# Altium schematic sheet 1_POWER.SchDoc
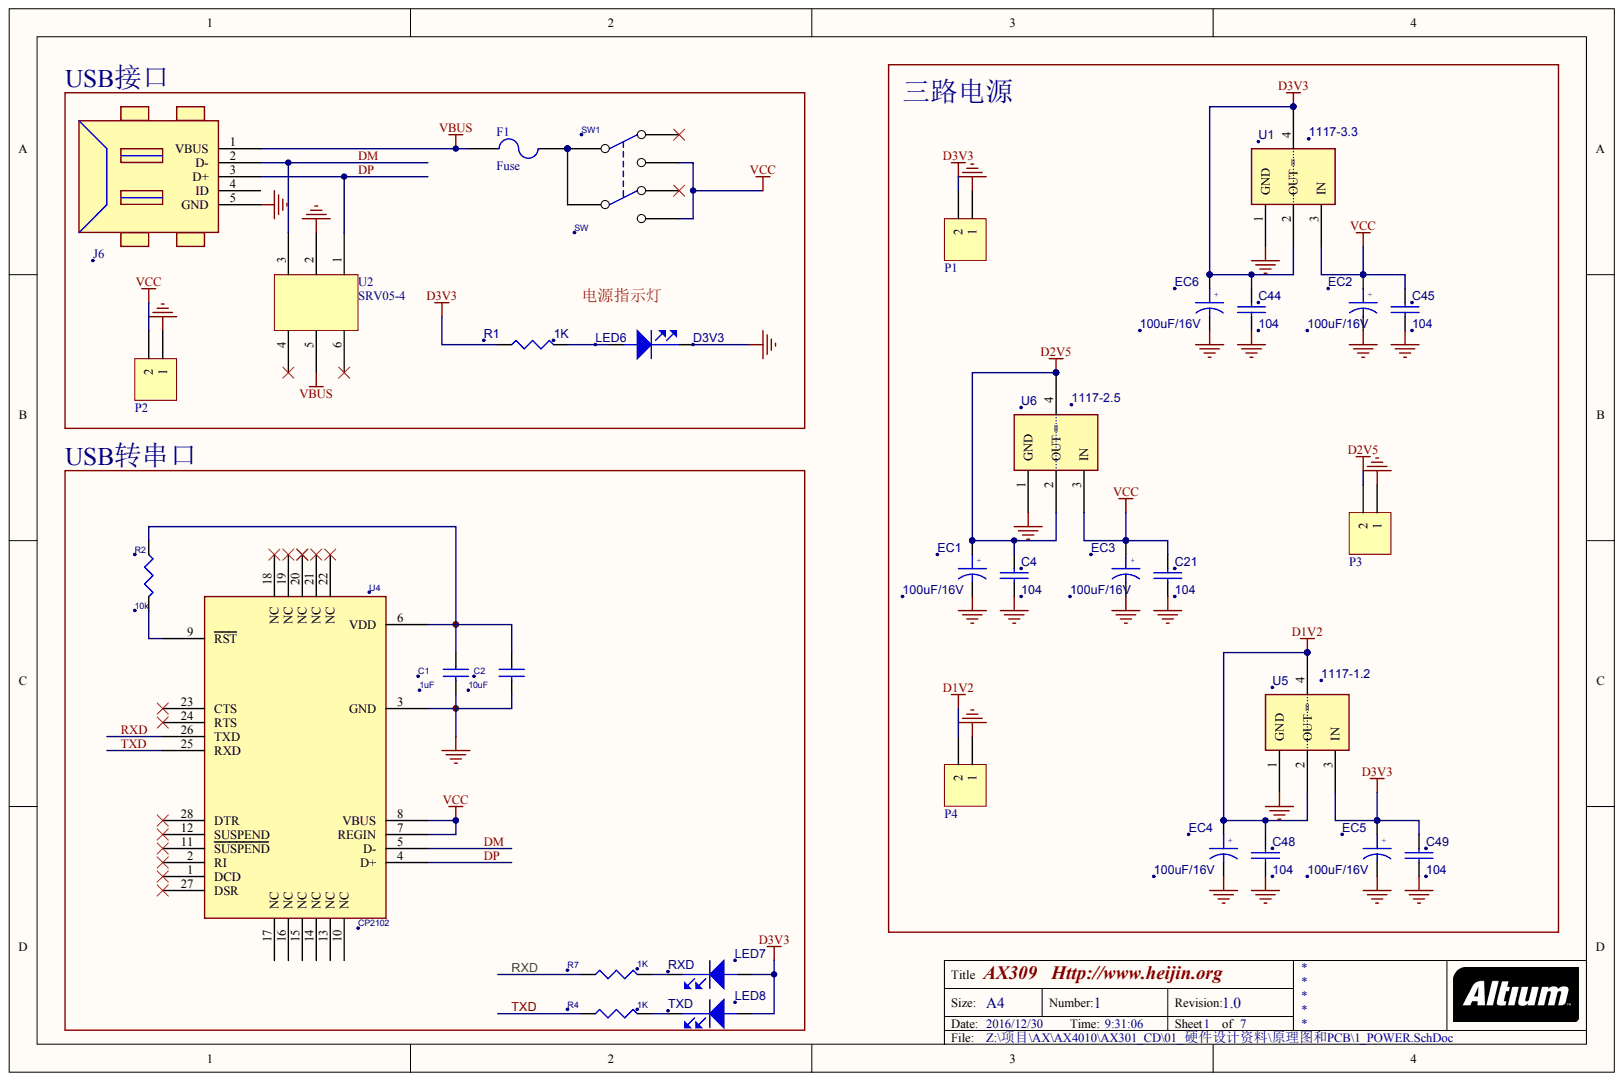
[diagram p0: 1_POWER.SchDoc]

## Parts
C1  1uF
C2  10uF
C4  104
C21  104
C44  104
C45  104
C48  104
C49  104
EC1  100uF/16V
EC2  100uF/16V
EC3  100uF/16V
EC4  100uF/16V
EC5  100uF/16V
EC6  100uF/16V
F1  Fuse
J6  EC2  (value not drawn)
LED6  D3V3
LED7  RXD
LED8  TXD
P1  3.3V  (value not drawn)
P2  5V  (value not drawn)
P3  3.3V  (value not drawn)
P4  1.2V  (value not drawn)
R1  1K
R2  10k
R4  1K
R7  1K
SW1  SW
U1  1117-3.3
U2  SRV05-4
U4  CP2102
U5  1117-1.2
U6  1117-2.5

## Nets
D1V2: C48.1 EC4.1 P4.2 U5.2(OUT) U5.4(=)
D2V5: C4.1 EC1.1 P3.2 U6.2(OUT) U6.4(=)
D3V3: C44.1 C49.1 EC5.1 EC6.1 LED7.1 LED8.1 P1.2 R1.1 U1.2(OUT) U1.4(=) U5.3(IN)
GND: C1.2 C2.2 C4.2 C21.2 C44.2 C45.2 C48.2 C49.2 EC1.2 EC2.2 EC3.2 EC4.2 EC5.2 EC6.2 J6.5(GND) LED6.2 P1.1 P2.1 P3.1 P4.1 U1.1(GND) U2.2 U4.3(GND) U5.1(GND) U6.1(GND)
VBUS: F1.1 J6.1(VBUS) U2.5
VCC: C21.1 C45.1 EC2.1 EC3.1 P2.2 SW1.3 SW1.6 U1.3(IN) U4.7(REGIN) U4.8(VBUS) U6.3(IN)
DM: J6.2(D-) U2.3 U4.5(D-)
DP: J6.3(D+) U2.1 U4.4(D+)
RXD: R7.1 U4.26(TXD)
TXD: R4.1 U4.25(RXD)
NetC1_1: C1.1 C2.1 R2.2 U4.6(VDD)
NetF1_2: F1.2 SW1.2 SW1.5
NetLED6_1: LED6.1 R1.2
NetLED7_2: LED7.2 R7.2
NetLED8_2: LED8.2 R4.2
NetR2_1: R2.1 U4.9(RST)

Not connected: J6 4(ID); SW1 1 4; U2 4 6; U4 1(DCD) 2(RI) 10(NC) 11(SUSPEND) 12(SUSPEND) 13(NC) 14(NC) 15(NC) 16(NC) 17(NC) 18(NC) 19(NC) 20(NC) 21(NC) 22(NC) 23(CTS) 24(RTS) 27(DSR) 28(DTR)

## Library data of the parts
J6 = (no symbol name): R50: J5
C1 C2 C4 C21 C44 C45 C48 C49 EC1-EC6 F1 LED6-LED8 P1-P4 R1 R2 R4 R7 SW1 U1 U2 U4-U6 = (no symbol name)
  F1: Published: 8-Jun-2000; LatestRevisionDate: 17-Jul-2002; LatestRevisionNote: Re-released for DXP Platform.; PackageReference: PIN-W2/E2.8; PackageDescription: Thru-Hole; 2 Leads
  P1-P4: LatestRevisionDate: 17-Jul-2002; LatestRevisionNote: Re-released for DXP Platform.
  F1 P1-P4: Publisher: Altium Limited
